# Altium schematic sheet Microcontroller_schematic1.SchDoc
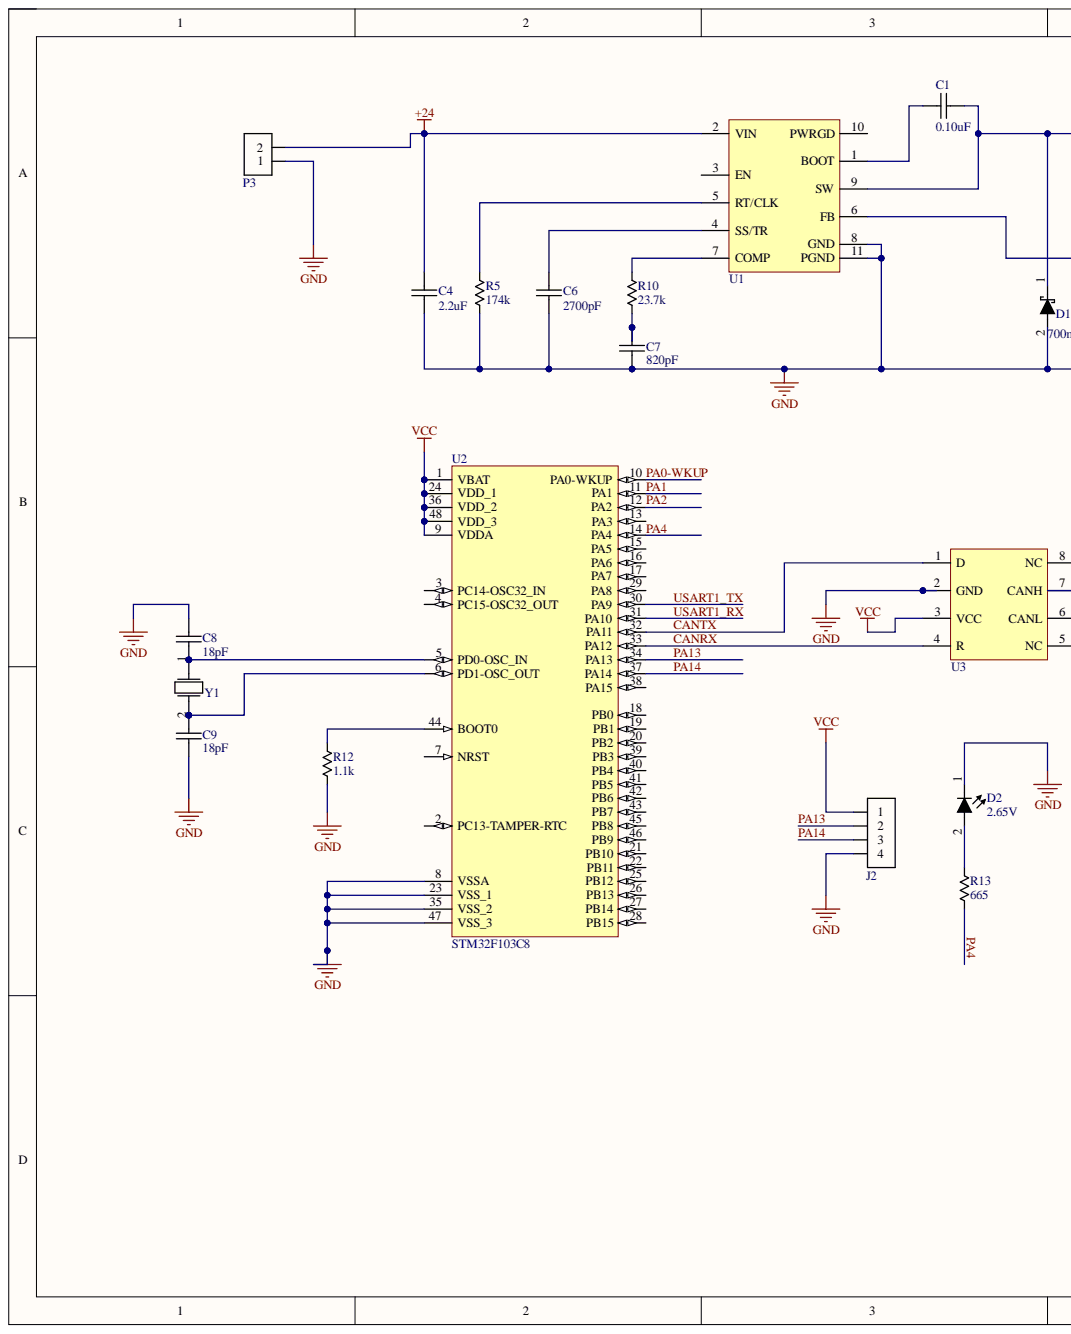
[diagram p0: Microcontroller_schematic1.SchDoc, left]
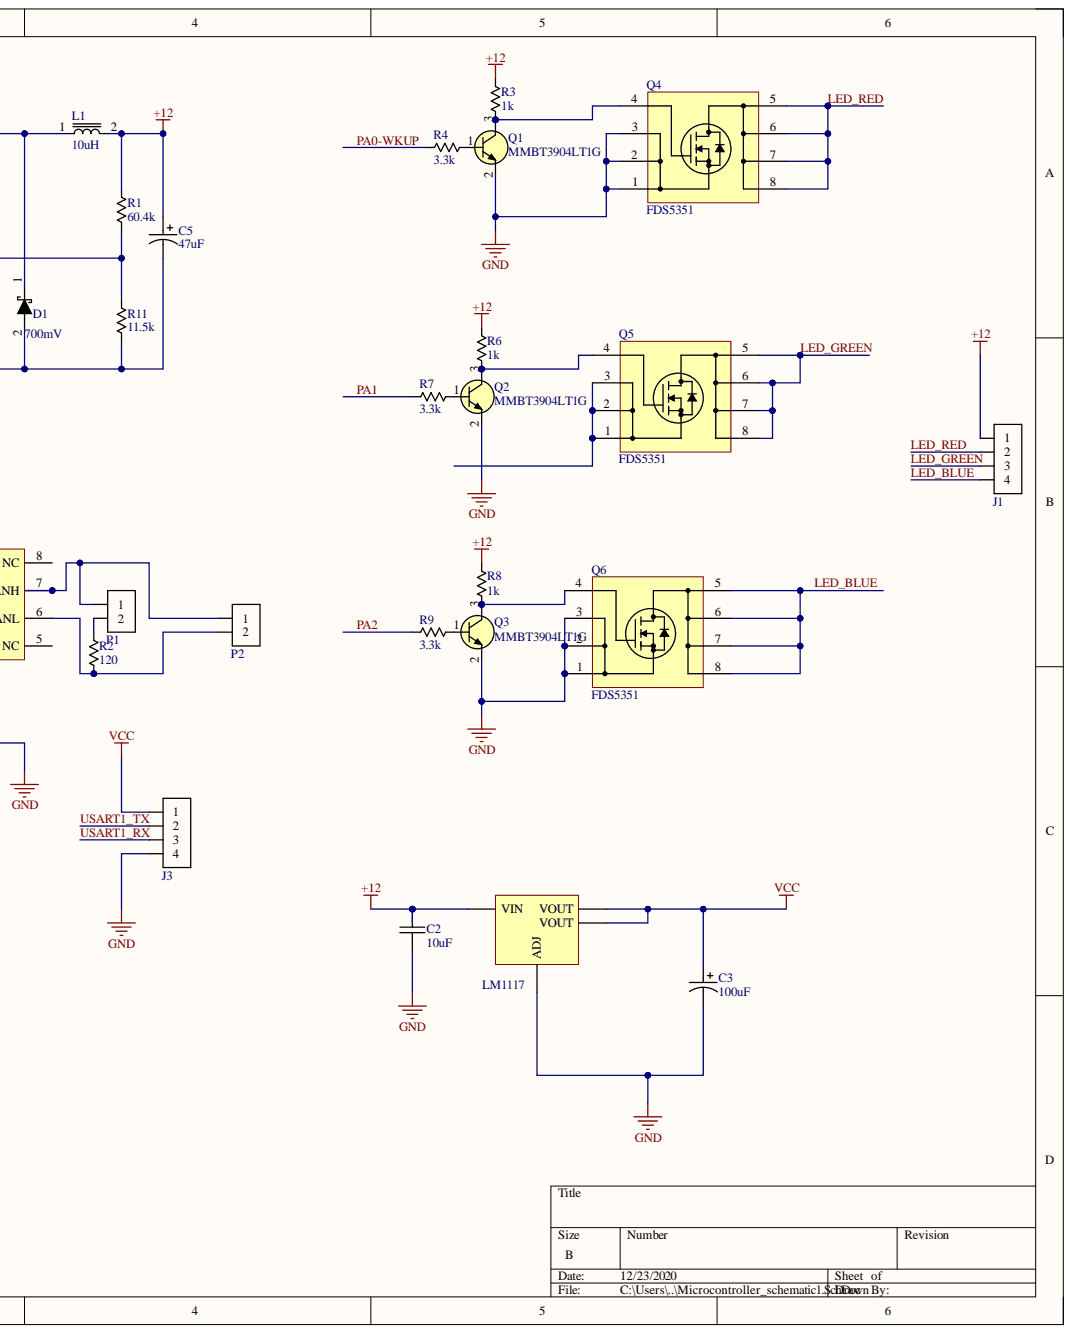
[diagram p1: Microcontroller_schematic1.SchDoc, right]

## Parts
C1  0.10uF  [chip_0805]
C2  10uF  [chip_1206]
C3  100uF  [chip_2917_AVX_TAJD]
C4  2.2uF  [chip_0603]
C5  47uF  [CP_NICHICON_UUX_10x10]
C6  2700pF  [chip_0805]
C7  820pF  [chip_0805]
C8  18pF  [chip_0603]
C9  18pF  [chip_0603]
D1  700mV  [do-214ab]
D2  2.65V  [chip_0603_LED]
J1  conn-4x1_0  [TERM_3.50MM_4x1_0]  (value not drawn)
J2  conn-4x1_0  [HDDR_4x1_0]  (value not drawn)
J3  conn-4x1_0  [HDDR_4x1_0]  (value not drawn)
L1  Inductance: 10uH  [VISHAY_IHLP3232DZ]  (hidden comment: ind_1)
LM1117  LM1117  [sot-223-4]
P1  conn-2x1_0  [HDDR_2x1_0]  (value not drawn)
P2  conn-2x1_0  [TERM_3.50MM_2x1_0]  (value not drawn)
P3  conn-2x1_0  [TERM_3.50MM_2x1_0]  (value not drawn)
Q1  MMBT3904LT1G  [SOT-23-3_1]
Q2  MMBT3904LT1G  [SOT-23-3_1]
Q3  MMBT3904LT1G  [SOT-23-3_1]
Q4  FDS5351  [SO-8]
Q5  FDS5351  [SO-8]
Q6  FDS5351  [SO-8]
R1  60.4k  [chip_0805]
R2  120  [chip_0805]
R3  1k  [chip_0805]
R4  3.3k  [chip_0603]
R5  174k  [chip_0805]
R6  1k  [chip_0805]
R7  3.3k  [chip_0603]
R8  1k  [chip_0805]
R9  3.3k  [chip_0603]
R10  23.7k  [chip_0805]
R11  11.5k  [chip_0805]
R12  1.1k  [chip_0603]
R13  665  [chip_0805]
U1  TPS54561  [WSON-10_0]  (value not drawn)
U2  STM32F103C8  [LQFP48_0]
U3  SN65HVD232  [SO-8]  (value not drawn)
Y1  Load Capacitance: 18pF  [ABLS_AT49_OSC]  (hidden comment: osc_xtal_2p_0)

## Nets
+12: C2.1 C5.1 J1.1 L1.2 LM1117.3(VIN) R1.1 R3.1 R6.1 R8.1
+24: C4.1 P3.2 U1.2(VIN)
GND: C2.2 C3.2 C4.2 C5.2 C6.2 C7.2 C8.1 C9.2 D1.2 D2.1 J2.4 J3.4 LM1117.1(ADJ) P3.1 Q1.2 Q2.2 Q3.2 Q4.1 Q4.2 Q4.3 Q6.1 Q6.2 Q6.3 R5.2 R11.2 R12.2 U1.8(GND) U1.11(PGND) U2.8(VSSA) U2.23(VSS_1) U2.35(VSS_2) U2.47(VSS_3) U3.2(GND)
VCC: C3.1 J2.1 J3.1 LM1117.2(VOUT) LM1117.4(VOUT) U2.1(VBAT) U2.9(VDDA) U2.24(VDD_1) U2.36(VDD_2) U2.48(VDD_3) U3.3(VCC)
CANRX: U2.33(PA12) U3.4(R)
CANTX: U2.32(PA11) U3.1(D)
LED_BLUE: J1.4 Q6.5 Q6.6 Q6.7 Q6.8
LED_GREEN: J1.3 Q5.5 Q5.6 Q5.7 Q5.8
LED_RED: J1.2 Q4.5 Q4.6 Q4.7 Q4.8
PA0-WKUP: R4.2 U2.10(PA0-WKUP)
PA1: R7.2 U2.11(PA1)
PA2: R9.2 U2.12(PA2)
PA4: R13.2 U2.14(PA4)
PA13: J2.2 U2.34(PA13)
PA14: J2.3 U2.37(PA14)
USART1_RX: J3.3 U2.31(PA10)
USART1_TX: J3.2 U2.30(PA9)
NetC1_1: C1.1 D1.1 L1.1 U1.9(SW)
NetC1_2: C1.2 U1.1(BOOT)
NetC6_1: C6.1 U1.4(SS/TR)
NetC7_1: C7.1 R10.2
NetC8_2: C8.2 U2.5(PD0-OSC_IN) Y1.1
NetC9_1: C9.1 U2.6(PD1-OSC_OUT) Y1.2
NetD2_2: D2.2 R13.1
NetP1_1: P1.1 P2.1 U3.7(CANH)
NetP1_2: P1.2 R2.1
NetP2_2: P2.2 R2.2 U3.6(CANL)
NetQ1_1: Q1.1 R4.1
NetQ1_3: Q1.3 Q4.4 R3.2
NetQ2_1: Q2.1 R7.1
NetQ2_3: Q2.3 Q5.4 R6.2
NetQ3_1: Q3.1 R9.1
NetQ3_3: Q3.3 Q6.4 R8.2
NetQ5_1: Q5.1 Q5.2 Q5.3
NetR1_2: R1.2 R11.1 U1.6(FB)
NetR5_1: R5.1 U1.5(RT/CLK)
NetR10_1: R10.1 U1.7(COMP)
NetR12_1: R12.1 U2.44(BOOT0)

Not connected: U1 3(EN) 10(PWRGD); U2 2(PC13-TAMPER-RTC) 3(PC14-OSC32_IN) 4(PC15-OSC32_OUT) 7(NRST) 13(PA3) 15(PA5) 16(PA6) 17(PA7) 18(PB0) 19(PB1) 20(PB2) 21(PB10) 22(PB11) 25(PB12) 26(PB13) 27(PB14) 28(PB15) 29(PA8) 38(PA15) 39(PB3) 40(PB4) 41(PB5) 42(PB6) 43(PB7) 45(PB8) 46(PB9); U3 5(NC) 8(NC)

## Library data of the parts
C1 = CAP-00069: CAP, 0.10uF, 10%, 16V, X7R, 0805; Manufacturer: Murata; Manufacturer PN: GRM219R71C104KA01D; Capacitance: 0.10uF; Component Kind: Standard; Library Name: Capacitors$.IntLib; Part Number: CAP-00069; Tolerance: 10%; Type: X7R; Voltage Rating: 16V
C2 = CAP-00539: CAP, 10uF, ±10%, 25V, X7R, 1206; Manufacturer: Samsung; Manufacturer PN: CL31B106KAHNNNE; Capacitance: 10uF; Component Kind: Standard; Library Name: Capacitors$.IntLib; Part Number: CAP-00539; Tolerance: ±10%; Type: X7R; Voltage Rating: 25V
C3 = CAP-00550: CAP, 100uF, ±10%, 16V, Tantalum, 2917; Manufacturer: AVX; Manufacturer PN: TAJD107K016RNJ; Capacitance: 100uF; Component Kind: Standard; Cost: $0.94; Library Name: Capacitors$.IntLib; Part Number: CAP-00550; Tolerance: ±10%; Type: Tantalum; Voltage Rating: 16V
C4 = CAP-00512: CAP, 2.2uF, ±10%, 10V, X7R, 0603; Manufacturer: Murata; Manufacturer PN: GRM188R71A225KE15D; Capacitance: 2.2uF; Component Kind: Standard; Library Name: Capacitors$.IntLib; Part Number: CAP-00512; Tolerance: ±10%; Type: X7R; Voltage Rating: 10V
C5 = CAP-00567: CAP, 47uF, ±20%, 100V, Al, SMD; Manufacturer: Nichicon; Manufacturer PN: UUX2A470MNL1GS; Capacitance: 47uF; Component Kind: Standard; Cost: $0.74; Library Name: Capacitors$.IntLib; Part Number: CAP-00567; Tolerance: ±20%; Type: Al; Voltage Rating: 100V
C6 = CAP-00024: CAP, 2700pF, 10%, 50V, X7R, 0805; Manufacturer: Murata; Manufacturer PN: GRM216R71H272KA01D; Capacitance: 2700pF; Component Kind: Standard; Library Name: Capacitors$.IntLib; Part Number: CAP-00024; Tolerance: 10%; Type: X7R; Voltage Rating: 50V
C7 = CAP-00008: CAP, 820pF, 10%, 50V, X7R, 0805; Manufacturer: Murata; Manufacturer PN: GRM216R71H821KA01D; Capacitance: 820pF; Component Kind: Standard; Library Name: Capacitors$.IntLib; Part Number: CAP-00008; Tolerance: 10%; Type: X7R; Voltage Rating: 50V
C8 C9 = CAP-00409: CAP, 18pF, ±2%, 50V, C0G, 0603; Manufacturer: Murata; Manufacturer PN: GRM1885C1H180GA01D; Capacitance: 18pF; Component Kind: Standard; Library Name: Capacitors$.IntLib; Part Number: CAP-00409; Tolerance: ±2%; Type: C0G; Voltage Rating: 50V
D1 = DIO-00048: Dio, Schottky, 5A, 700mV, 60V, SMC; Manufacturer: Diodes Incorporated; Manufacturer PN: B560C-13-F; Component Kind: Standard; Cost: 0.5; Current Rating: 5A; Forward Voltage: 700mV; Library Name: Diodes$.IntLib; Part Number: DIO-00048; Reverse Breakdown Voltage: 60V; Type: Schottky
D2 = DIO-00050: LED, Green, 0603, Low current, HELI2 Series; Manufacturer: Kingbright; Manufacturer PN: APT1608LZGCK; Current Rating: 30mA; Power Rating: 120mW; Reverse Breakdown Voltage: 5V; Component Kind: Standard; Cost: 0.58; Forward Voltage: 2.65V; Library Name: Diodes$.IntLib; Part Number: DIO-00050; Type: LED
J1 = CONN-00024: Term Blk, 4 Pos, 8A, 3.5mm Pitch, Screwless; Manufacturer: Phoenix Contact; Manufacturer PN: 1861959; Current: 8; Levels: 1; Positions per level: 4; Component Kind: Standard; Cost: 1.67; Library Name: Connectors$.IntLib; Part Number: CONN-00024; Type: Terminal Block; Weight: 2.3
J2 J3 = CONN-00029: Header 4x1, 0.1"; Levels: 1; Positions per level: 4; Component Kind: Standard; Library Name: Connectors$.IntLib; Part Number: CONN-00029; Type: Header
L1 = MAG-00106: Ind, 10uH, 20%, 5.2A (9A sat.), 0.0599 Ohm; Manufacturer: Vishay Dale; Manufacturer PN: IHLP3232DZER100M01; DCR: 59.9mOhm; Component Kind: Standard; Cost: 1.65; Current Rating: 5.2A; Part Number: MAG-00106; Tolerance: 20%; Library Name: Magnetics$.IntLib
LM1117 = PWREG-00018: REG, Linear, Fixed 3V3, 800mA, SOT-223; Manufacturer: TI; Manufacturer PN: LM1117MPX-3.3/NOPB; Voltage Range In: 4.5 - 15V; Voltage Range Out: 3.3V; Component Kind: Standard; Cost: 1.14; Fixed/Adj: Fixed; Library Name: Power Reg$.IntLib; Max Current: 800mA; Part Number: PWREG-00018; Reg Type: Linear
P1 = CONN-00055: Header, 2x1, 0.1"; Manufacturer: Samtec; Manufacturer PN: TSW-102-07-F-S; Levels: 1; Positions per level: 2; Component Kind: Standard; Cost: 0.2; Library Name: Connectors$.IntLib; Part Number: CONN-00055; Type: Header
P2 P3 = CONN-00023: Term Blk, 2 Pos, 8A, 3.5mm Pitch, Screwless; Manufacturer: Phoenix Contact; Manufacturer PN: 1861933; Current: 8; Levels: 1; Positions per level: 2; Component Kind: Standard; Cost: 0.79; Library Name: Connectors$.IntLib; Part Number: CONN-00023; Type: Terminal Block; Weight: 1.13
Q1-Q3 = XSTR-00037: XSTR, NPN, 200mA, 40V, Vce 0.3V, SOT-23-3; Manufacturer: ON Semi; Max Power: 300mW; Component Kind: Standard; Cost: 0.12; Library Name: Transistors$.IntLib; Manufacturer PN: MMBT3904LT1G; Max Current: 200mA; Max Voltage: 40V; Part Number: XSTR-00037; Type: NPN; Vgs/Vce: 0.3V
Q4-Q6 = XSTR-00034: XSTR NFET, 6.1A, 60V, 5W, SOIC-8; Manufacturer: ON Semi; Vgs/Vce: 2.0V; Component Kind: Standard; Cost: 0.8; Library Name: Transistors$.IntLib; Manufacturer PN: FDS5351; Max Current: 6.1A; Max Power: 5W; Max Voltage: 60V; Part Number: XSTR-00034; Type: NFET
R1 = RES-00531: RES SMD 60.4K OHM 1% 1/8W 0805; Manufacturer: Rohm Semiconductor; Manufacturer PN: KTR10EZPF6042; Power Rating: 0.125W; Component Kind: Standard; Library Name: Resistors$.IntLib; Part Number: RES-00531; Resistance: 60.4k; Tolerance: 1%
R2 = RES-00183: RES SMD 120 OHM 1% 1/8W 0805; Manufacturer: Rohm Semiconductor; Manufacturer PN: KTR10EZPF1200; Power Rating: 0.125W; Component Kind: Standard; Library Name: Resistors$.IntLib; Part Number: RES-00183; Resistance: 120; Tolerance: 1%
R3 R6 R8 = RES-00361: RES SMD 1K OHM 1% 1/8W 0805; Manufacturer: Rohm Semiconductor; Manufacturer PN: KTR10EZPF1001; Power Rating: 0.125W; Component Kind: Standard; Library Name: Resistors$.IntLib; Part Number: RES-00361; Resistance: 1k; Tolerance: 1%
R4 R7 R9 = RES-00419: RES SMD 3.3K OHM 1% 1/4W 0603; Manufacturer: Panasonic; Manufacturer PN: ERJ-PA3F3301V; Power Rating: 0.25W; Component Kind: Standard; Library Name: Resistors$.IntLib; Part Number: RES-00419; Resistance: 3.3k; Tolerance: 1%
R5 = RES-00350: RES SMD 174K OHM 1% 1/8W 0805; Manufacturer: Rohm Semiconductor; Manufacturer PN: KTR10EZPF1743; Power Rating: 0.125W; Component Kind: Standard; Library Name: Resistors$.IntLib; Part Number: RES-00350; Resistance: 174k; Tolerance: 1%
R10 = RES-00392: RES SMD 23.7K OHM 1% 1/8W 0805; Manufacturer: Rohm Semiconductor; Manufacturer PN: KTR10EZPF2372; Power Rating: 0.125W; Component Kind: Standard; Library Name: Resistors$.IntLib; Part Number: RES-00392; Resistance: 23.7k; Tolerance: 1%
R11 = RES-00309: RES SMD 11.5K OHM 1% 1/8W 0805; Manufacturer: Rohm Semiconductor; Manufacturer PN: KTR10EZPF1152; Power Rating: 0.125W; Component Kind: Standard; Library Name: Resistors$.IntLib; Part Number: RES-00309; Resistance: 11.5k; Tolerance: 1%
R12 = RES-00264: RES SMD 1.1K OHM 1% 1/4W 0603; Manufacturer: Panasonic; Manufacturer PN: ERJ-PA3F1101V; Power Rating: 0.25W; Component Kind: Standard; Library Name: Resistors$.IntLib; Part Number: RES-00264; Resistance: 1.1k; Tolerance: 1%
R13 = RES-00248: RES SMD 665 OHM 1% 1/8W 0805; Manufacturer: Rohm Semiconductor; Manufacturer PN: KTR10EZPF6650; Power Rating: 0.125W; Component Kind: Standard; Library Name: Resistors$.IntLib; Part Number: RES-00248; Resistance: 665; Tolerance: 1%
U1 = PWREG-00033: REG, SW Buck Adj, 5A, 4.5-60V, 2.5MHz, TPS54561; Manufacturer: TI; Manufacturer PN: TPS54561DPRR; Frequency: 100kHz-2.5MHz; Reg Type: SW Buck; Voltage Range Out: 0.8-58.8V; Component Kind: Standard; Cost: 5.52; Fixed/Adj: Adj; Library Name: Power Reg$.IntLib; Max Current: 5A; Part Number: PWREG-00033; Voltage Range In: 4.5-60V
U2 = UCTRL-00008: STM32 M3, LQFP-48; Manufacturer: ST; Component Kind: Standard; Library Name: Microcontrollers$.IntLib; Manufacturer PN: STM32F103C8; Part Number: UCTRL-00008
U3 = IFACE-00008: CANbus Transceiver, Single channel, SN65HVD232; Manufacturer: TI; Manufacturer PN: SN65HVD232DR; Component Kind: Standard; Cost: 2.01; Library Name: Interfaces$.IntLib; Part Number: IFACE-00008
Y1 = OSC-00002: XTAL, 8MHz, 18pF, 20ppm; Manufacturer: Abracon; Manufacturer PN: ABLS-8.000MHZ-B2-T; ESR: 80; Frequency: 8.000M; Stability: 50ppm; Component Kind: Standard; Library Name: Oscillators$.IntLib; Part Number: OSC-00002; Tolerance: 20ppm
